# Altium schematic sheet Top.SchDoc(Top)
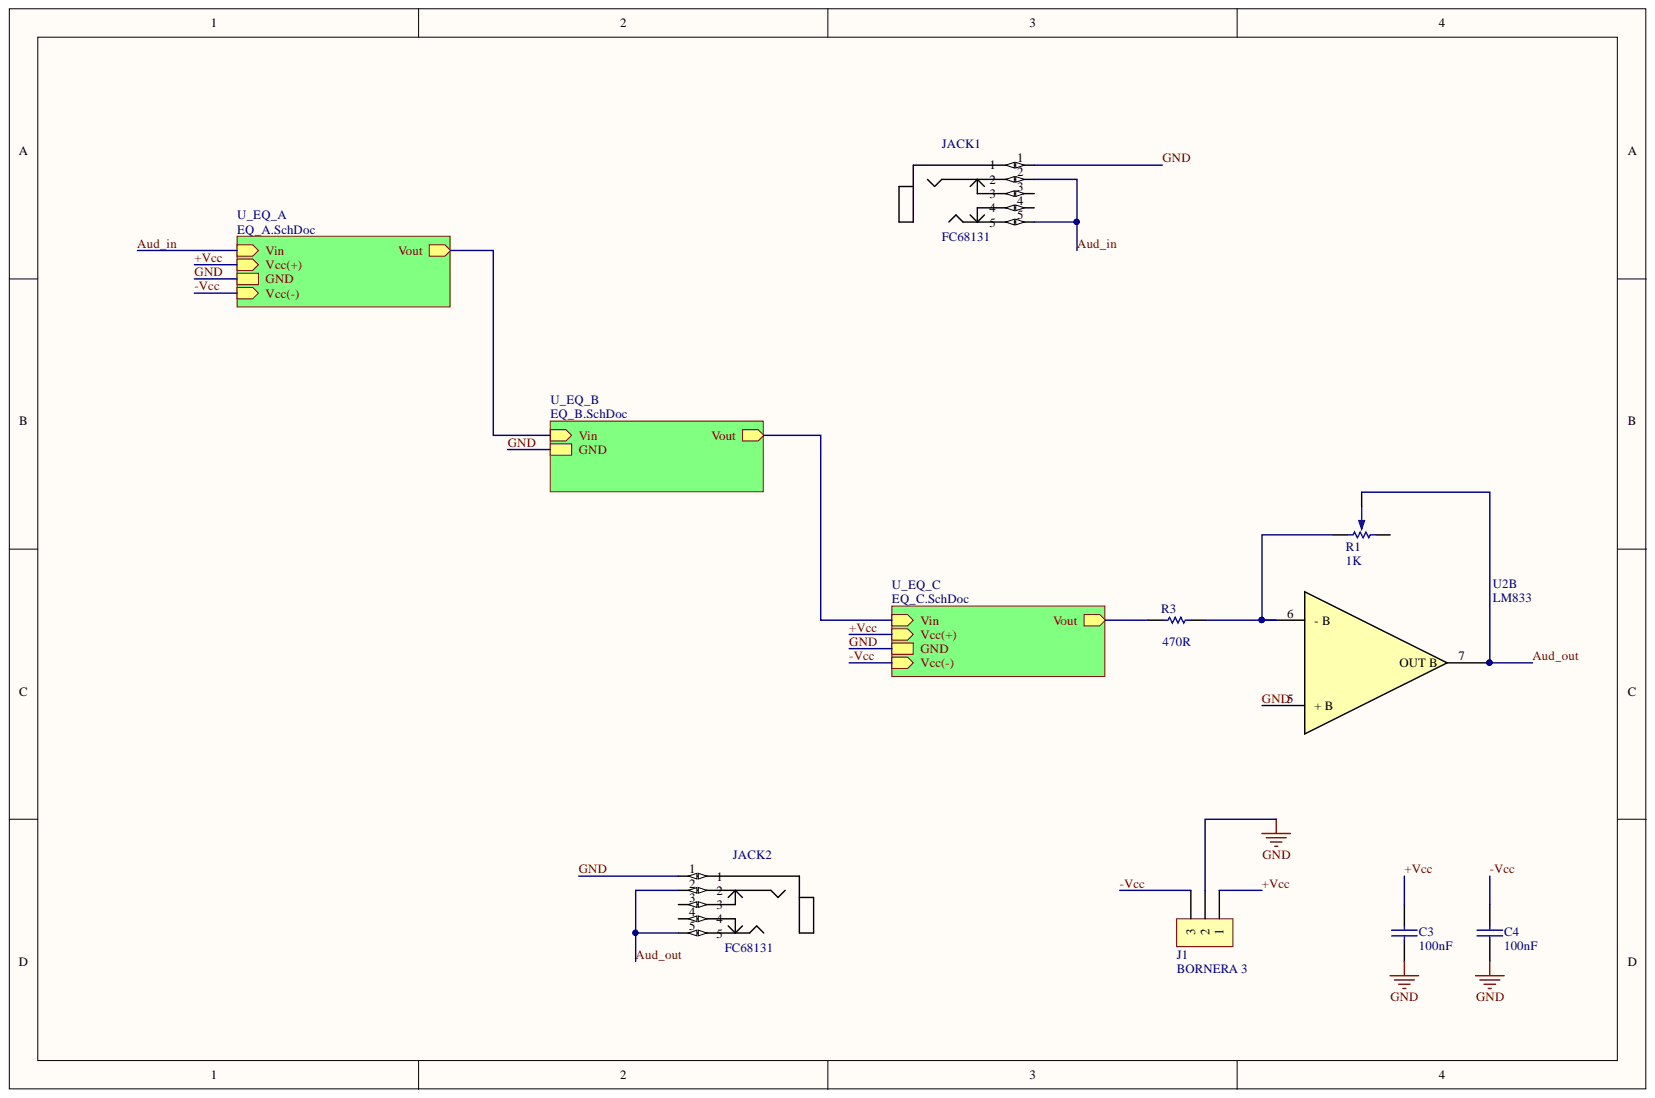
[diagram p0: Top.SchDoc]

## Parts
C3  100nF  [CAP200]
C4  100nF  [CAP200]
J1  BORNERA 3  [BORNERA3]
JACK1  FC68131  [*FC68131-5P]
JACK2  FC68131  [*FC68131-5P]
R1  1K  [POTENCIOMETRO]
R3  470R  [0805]
U2  LM833  [DIP8]  (part B)

## Nets
+Vcc: C3.1 J1.1
-Vcc: C4.2 J1.3
Aud_in: JACK1.2 JACK1.5
Aud_out: JACK2.2 JACK2.5 R1.2 U2.7(OUT_B)
GND: C3.2 C4.1 J1.2 JACK1.1 JACK2.1 U2.5(+_B)
NetJACK1_3: JACK1.3
NetJACK1_4: JACK1.4
NetJACK2_3: JACK2.3
NetJACK2_4: JACK2.4
NetR1_1: R1.1 R3.2 U2.6(-_B)
NetR1_3: R1.3
NetR3_1: R3.1
Vout:

## Library data of the parts
C3 C4 = CAP: Library Name: LIBEBAL.IntLib; Component Kind: Standard
JACK1 JACK2 = FC68131: Stereo Jack, 3.5mm, 3pos, Pcb; MF: Cliff; SnapEDA_Link: https://www.snapeda.com/parts/FC68131/Cliff%20Electronics/view-part/?ref=snap; Price: None; Package: None; MP: FC68131; Library Name: FC68131.IntLib; Component Kind: Standard; Availability: Unavailable
R1 = POTENCIOMETRO: Library Name: LIBEBAL.IntLib; Component Kind: Standard
R3 = RES: Library Name: LIBEBAL.IntLib; Component Kind: Standard
U2 = LM833: Dual Audio Operational Amplifier; Library Name: LIBEBAL.IntLib; Component Kind: Standard
J1 = BORNERA 3: Library Name: LIBEBAL.IntLib; Component Kind: Standard
